# Altium schematic sheet RF_Front-End1.SchDoc
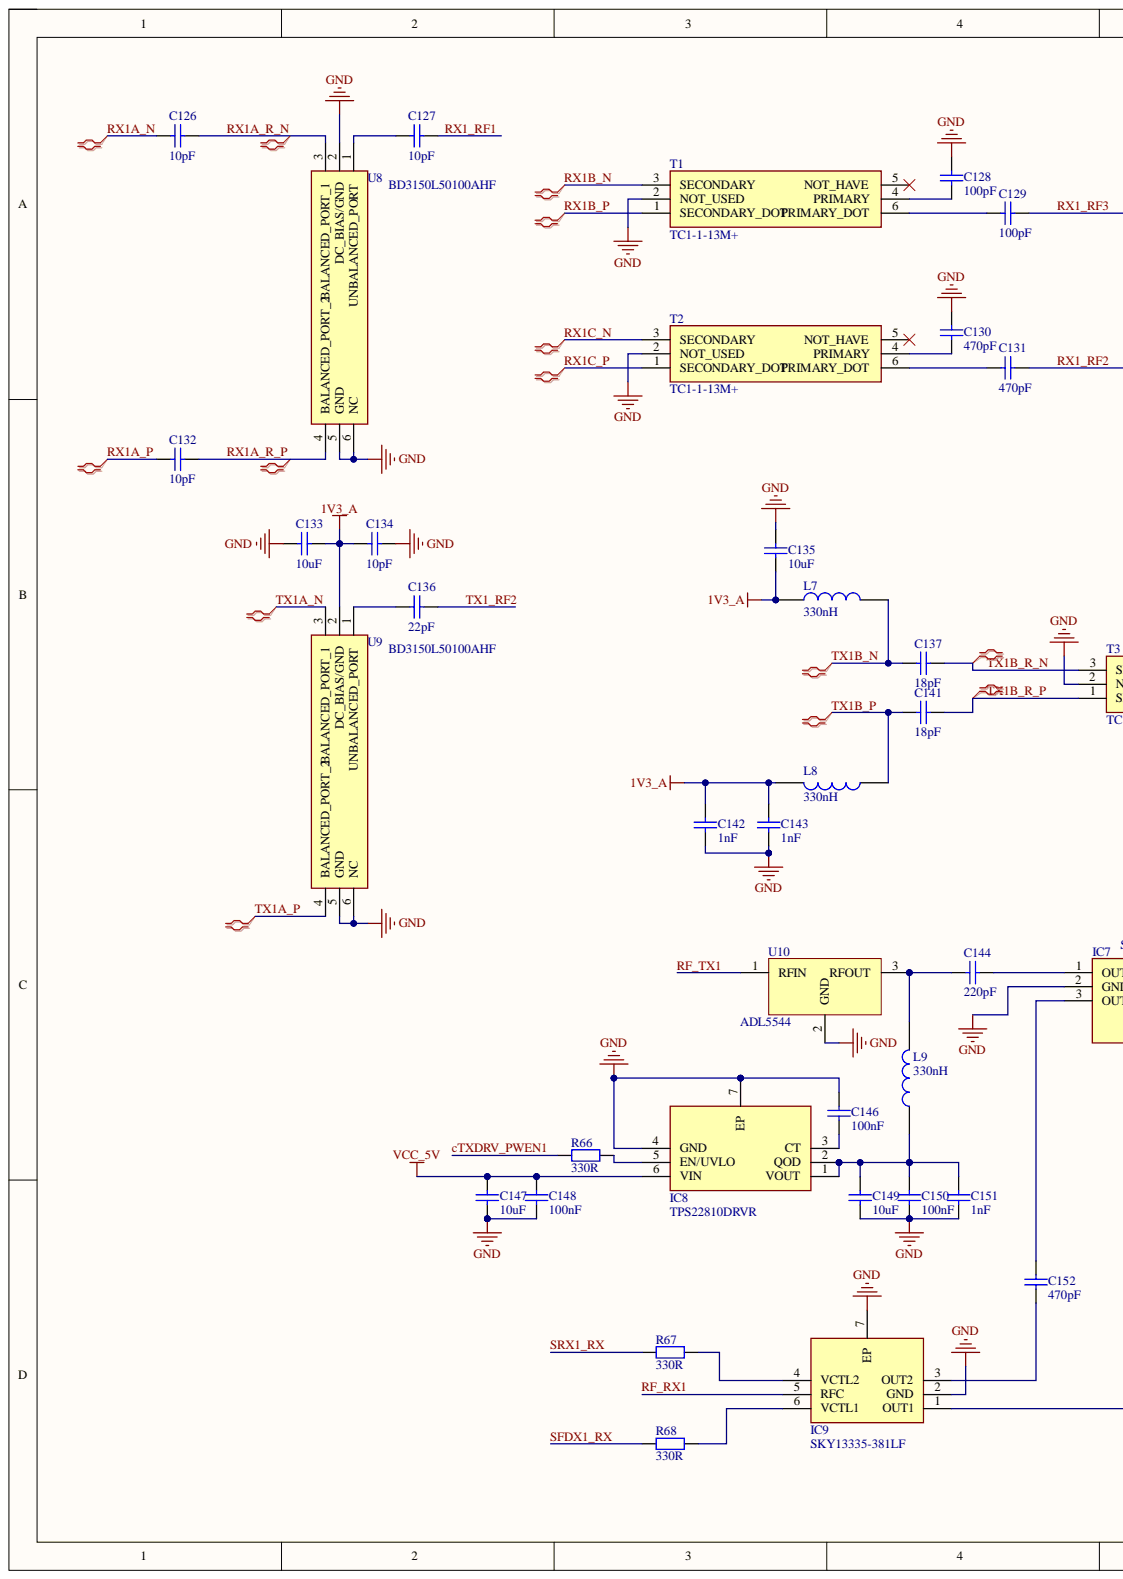
[diagram p0: RF_Front-End1.SchDoc, left]
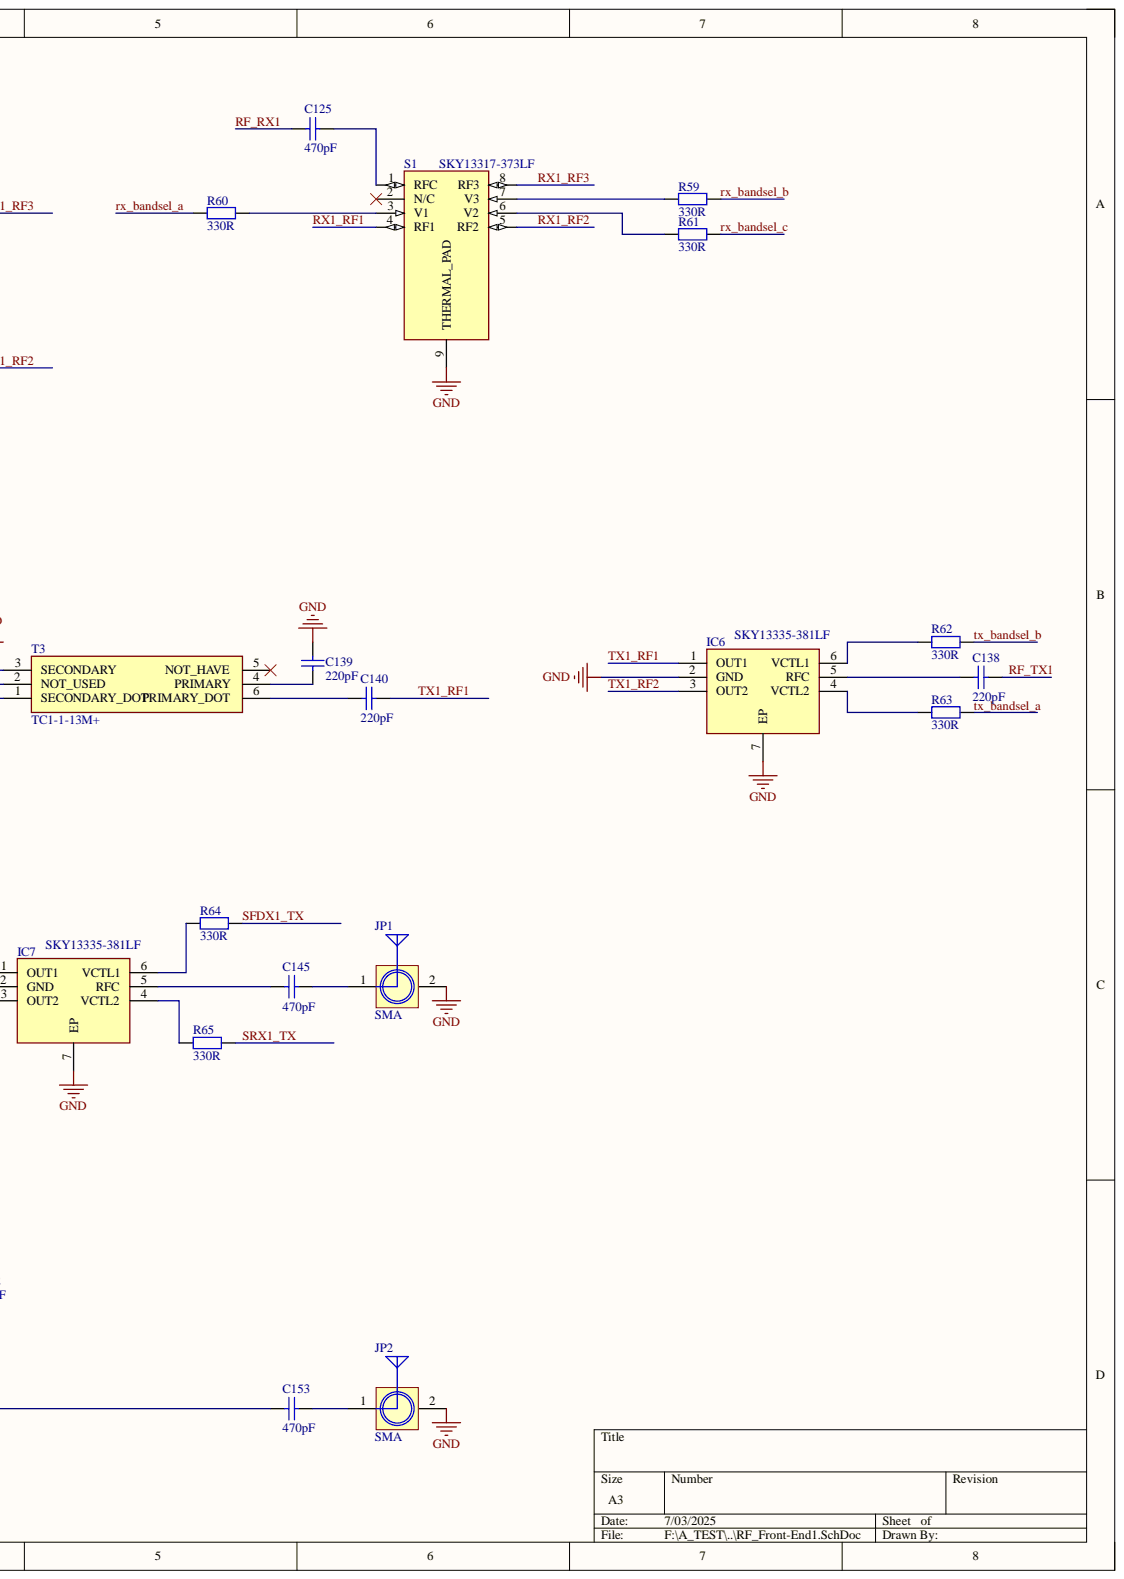
[diagram p1: RF_Front-End1.SchDoc, right]

## Parts
C125  470pF  [C 0402_L]
C126  10pF  [C 0402_L]
C127  10pF  [C 0402_L]
C128  100pF  [C 0402_L]
C129  100pF  [C 0402_L]
C130  470pF  [C 0402_L]
C131  470pF  [C 0402_L]
C132  10pF  [C 0402_L]
C133  10uF  [C 0402_L]
C134  10pF  [C 0402_L]
C135  10uF  [C 0402_L]
C136  22pF  [C 0402_L]
C137  18pF  [C 0402_L]
C138  220pF  [C 0402_L]
C139  220pF  [C 0402_L]
C140  220pF  [C 0402_L]
C141  18pF  [C 0402_L]
C142  1nF  [C 0402_L]
C143  1nF  [C 0402_L]
C144  220pF  [C 0402_L]
C145  470pF  [C 0402_L]
C146  100nF  [C 0402_L]
C147  10uF  [C 0603_L]
C148  100nF  [C 0402_L]
C149  10uF  [C 0603_L]
C150  100nF  [C 0402_L]
C151  1nF  [C 0402_L]
C152  470pF  [C 0402_L]
C153  470pF  [C 0402_L]
IC6  SKY13335-381LF  [SON50P200X150X50-7N]
IC7  SKY13335-381LF  [SON50P200X150X50-7N]
IC8  TPS22810DRVR  [SON65P200X200X80-7N]
IC9  SKY13335-381LF  [SON50P200X150X50-7N]
JP1  SMA  [SMA-KE - 0.5mm]
JP2  SMA  [SMA-KE - 0.5mm]
L7  330nH  [L 0402]
L8  330nH  [L 0402]
L9  330nH  [L 0603]
R59  330R  [R 0402_L]
R60  330R  [R 0402_L]
R61  330R  [R 0402_L]
R62  330R  [R 0402_L]
R63  330R  [R 0402_L]
R64  330R  [R 0402_L]
R65  330R  [R 0402_L]
R66  330R  [R 0402_L]
R67  330R  [R 0402_L]
R68  330R  [R 0402_L]
S1  SKY13317-373LF  [SON40P155X155X50-9N]
T1  TC1-1-13M+  [TC414]
T2  TC1-1-13M+  [TC414]
T3  TC1-1-13M+  [TC414]
U8  BD3150L50100AHF  [BD3150L50100AHF]
U9  BD3150L50100AHF  [BD3150L50100AHF]
U10  ADL5544  [SOT89]

## Nets
1V3_A: C133.1 C134.2 C135.1 C142.1 C143.1 L7.1 L8.2 U9.2(DC_BIAS/GND)
GND: C128.1 C130.1 C133.2 C134.1 C135.2 C139.1 C142.2 C143.2 C146.2 C147.1 C148.1 C149.1 C150.1 C151.1 IC6.2(GND) IC6.7(EP) IC7.2(GND) IC7.7(EP) IC8.4(GND) IC8.7(EP) IC9.2(GND) IC9.7(EP) JP1.2 JP2.2 S1.9(THERMAL_PAD) T1.2(NOT_USED) T2.2(NOT_USED) T3.2(NOT_USED) U8.2(DC_BIAS/GND) U8.5(GND) U8.6(NC) U9.5(GND) U9.6(NC) U10.2
VCC_5V: C147.2 C148.2 IC8.6(VIN)
cTXDRV_PWEN1: R66.1
RF_RX1: C125.1 IC9.5(RFC)
RF_TX1: C138.1 U10.1
RX1_RF1: C127.1 S1.4(RF1)
RX1_RF2: C131.2 S1.5(RF2)
RX1_RF3: C129.2 S1.8(RF3)
RX1A_N: C126.2
RX1A_P: C132.2
RX1A_R_N: C126.1 U8.3(BALANCED_PORT_1)
RX1A_R_P: C132.1 U8.4(BALANCED_PORT_2)
RX1B_N: T1.3(SECONDARY)
RX1B_P: T1.1(SECONDARY_DOT)
RX1C_N: T2.3(SECONDARY)
RX1C_P: T2.1(SECONDARY_DOT)
rx_bandsel_a: R60.1
rx_bandsel_b: R59.2
rx_bandsel_c: R61.2
SFDX1_RX: R68.1
SFDX1_TX: R64.2
SRX1_RX: R67.1
SRX1_TX: R65.2
TX1_RF1: C140.2 IC6.1(OUT1)
TX1_RF2: C136.1 IC6.3(OUT2)
TX1A_N: U9.3(BALANCED_PORT_1)
TX1A_P: U9.4(BALANCED_PORT_2)
TX1B_N: C137.1 L7.2
TX1B_P: C141.1 L8.1
TX1B_R_N: C137.2 T3.3(SECONDARY)
TX1B_R_P: C141.2 T3.1(SECONDARY_DOT)
tx_bandsel_a: R63.2
tx_bandsel_b: R62.2
NetC125_2: C125.2 S1.1(RFC)
NetC127_2: C127.2 U8.1(UNBALANCED_PORT)
NetC128_2: C128.2 T1.4(PRIMARY)
NetC129_1: C129.1 T1.6(PRIMARY_DOT)
NetC130_2: C130.2 T2.4(PRIMARY)
NetC131_1: C131.1 T2.6(PRIMARY_DOT)
NetC136_2: C136.2 U9.1(UNBALANCED_PORT)
NetC138_2: C138.2 IC6.5(RFC)
NetC139_2: C139.2 T3.4(PRIMARY)
NetC140_1: C140.1 T3.6(PRIMARY_DOT)
NetC144_1: C144.1 IC7.1(OUT1)
NetC144_2: C144.2 L9.2 U10.3
NetC145_1: C145.1 JP1.1
NetC145_2: C145.2 IC7.5(RFC)
NetC146_1: C146.1 IC8.3(CT)
NetC149_2: C149.2 C150.2 C151.2 IC8.1(VOUT) IC8.2(QOD) L9.1
NetC152_1: C152.1 IC7.3(OUT2)
NetC152_2: C152.2 IC9.3(OUT2)
NetC153_1: C153.1 JP2.1
NetC153_2: C153.2 IC9.1(OUT1)
NetIC6_4: IC6.4(VCTL2) R63.1
NetIC6_6: IC6.6(VCTL1) R62.1
NetIC7_4: IC7.4(VCTL2) R65.1
NetIC7_6: IC7.6(VCTL1) R64.1
NetIC8_5: IC8.5(EN/UVLO) R66.2
NetIC9_4: IC9.4(VCTL2) R67.2
NetIC9_6: IC9.6(VCTL1) R68.2
NetR59_1: R59.1 S1.7(V3)
NetR60_2: R60.2 S1.3(V1)
NetR61_1: R61.1 S1.6(V2)

Not connected: S1 2(N/C); T1 5(NOT_HAVE); T2 5(NOT_HAVE); T3 5(NOT_HAVE)

## Library data of the parts
C125-C153 = Cap: 贴片电容; Component Kind: Standard; Library Name: A_Schlib.SchLib
  C125 C130 C131 C145 C152 C153: value: 470pF
  C126 C127 C132 C134: value: 10pF
  C128 C129: value: 100pF
  C133 C135 C147 C149: value: 10uF
  C136: value: 22pF
  C137 C141: value: 18pF
  C138-C140 C144: value: 220pF
  C142 C143 C151: value: 1nF
  C146 C148 C150: value: 100nF
IC6 IC7 IC9 = SKY13335-381LF: Integrated Circuit; Manufacturer_Name: Skyworks; Component Kind: Standard; Datasheet Link: https://eu.mouser.com/datasheet/2/472/SKY13335_381LF_201093D-1524822.pdf; Height: 0.5mm; Library Name: SamacSys.SchLib; Manufacturer_Part_Number: SKY13335-381LF; Mouser Part Number: 873-SKY13335-381LF; Mouser Price/Stock: https://www.mouser.co.uk/ProductDetail/Skyworks-Solutions-Inc/SKY13335-381LF?qs=WMHGlxXAKT8o8eJW4DHjzg%3D%3D
IC8 = TPS22810DRVR: Integrated Circuit; Manufacturer_Name: Texas Instruments; Arrow Part Number: TPS22810DRVR; Arrow Price/Stock: https://www.arrow.com/en/products/tps22810drvr/texas-instruments?region=nac; Component Kind: Standard; Datasheet Link: http://www.ti.com/lit/gpn/tps22810; Height: 0.8mm; Library Name: SamacSys.SchLib; Manufacturer_Part_Number: TPS22810DRVR; Mouser Part Number: 595-TPS22810DRVR; Mouser Price/Stock: https://www.mouser.co.uk/ProductDetail/Texas-Instruments/TPS22810DRVR?qs=5aG0NVq1C4wkfnc1%252B6LezA%3D%3D
JP1 JP2 = SMA: SMA-2.4G射频天线接口; 版权归属: 源创客; BOM名称: SMA-2.4G射频天线接口; Component Kind: Standard; Library Name: A_Schlib.SchLib; 学习资源: www.ooocke.com
L7-L9 = 电感: 包含功率; Component Kind: Standard; Library Name: A_Schlib.SchLib
R59-R68 = Res: 通用; Component Kind: Standard; Library Name: A_Schlib.SchLib; value: 330R
S1 = SKY13317-373LF: Switch; Manufacturer_Name: Skyworks; Arrow Part Number: SKY13317-373LF; Arrow Price/Stock: https://www.arrow.com/en/products/sky13317-373lf/skyworks-solutions?utm_currency=USD&region=nac; Component Kind: Standard; Datasheet Link: https://componentsearchengine.com/Datasheets/1/SKY13317-373LF.pdf; Height: 0.5mm; Library Name: SamacSys.SchLib; Manufacturer_Part_Number: SKY13317-373LF; Mouser Part Number: 873-SKY13317-373LF; Mouser Price/Stock: https://www.mouser.co.uk/ProductDetail/Skyworks-Solutions-Inc/SKY13317-373LF?qs=WMHGlxXAKT%2FS6%2Fi0J8S9Fw%3D%3D
T1-T3 = TC1-1-13M+: Transformer; Manufacturer_Name: Mini-Circuits; Component Kind: Standard; Datasheet Link: https://datasheet.datasheetarchive.com/originals/distributors/Datasheets-28/DSA-546322.pdf; Height: 4.06mm; Library Name: SamacSys.SchLib; Manufacturer_Part_Number: TC1-1-13M+; Mouser Part Number: 139-TC1-1-13M; Mouser Price/Stock: https://www.mouser.co.uk/ProductDetail/Mini-Circuits/TC1-1-13M%2b?qs=xZ%2FP%252Ba9zWqYek%252BZGAF2fWg%3D%3D
U8 U9 = BD3150L50100AHF: Undefined or Miscellaneous; Manufacturer_Name: ANAREN; Component Kind: Standard; Datasheet Link: https://cdn.ttm.com/repository/products/wireless-xinger/balun-transformers/BD3150L50100AHF/BD3150L50100AHF.pdf; Height: 0.74mm; Manufacturer_Part_Number: BD3150L50100AHF; Mouser Part Number: 620-BD3150L50100AHF; Mouser Price/Stock: https://www.mouser.co.uk/ProductDetail/Anaren/BD3150L50100AHF?qs=voMMqUKR%252ByDvMcYv7w2PZg%3D%3D
U10 = PGA-102+: Component Kind: Standard; Library Name: A_Schlib.SchLib
